# Altium schematic sheet Peripheral.SchDoc(Peripheral)
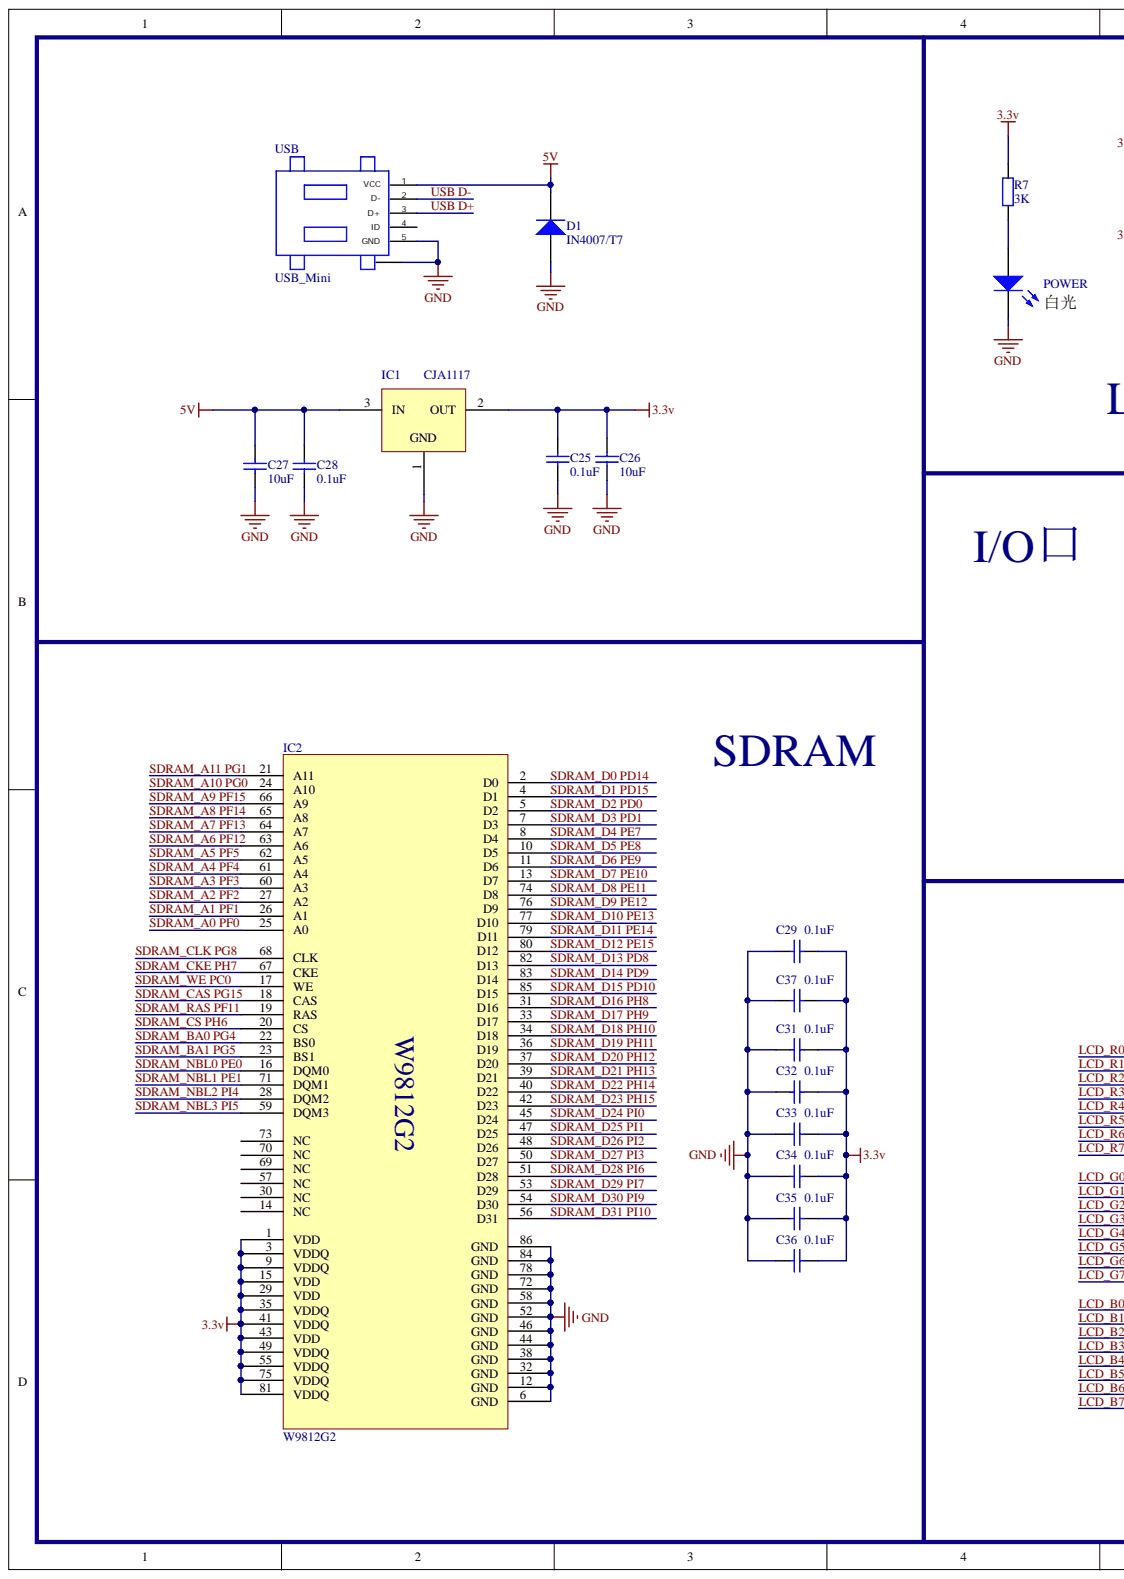
[diagram p0: Peripheral.SchDoc, left]
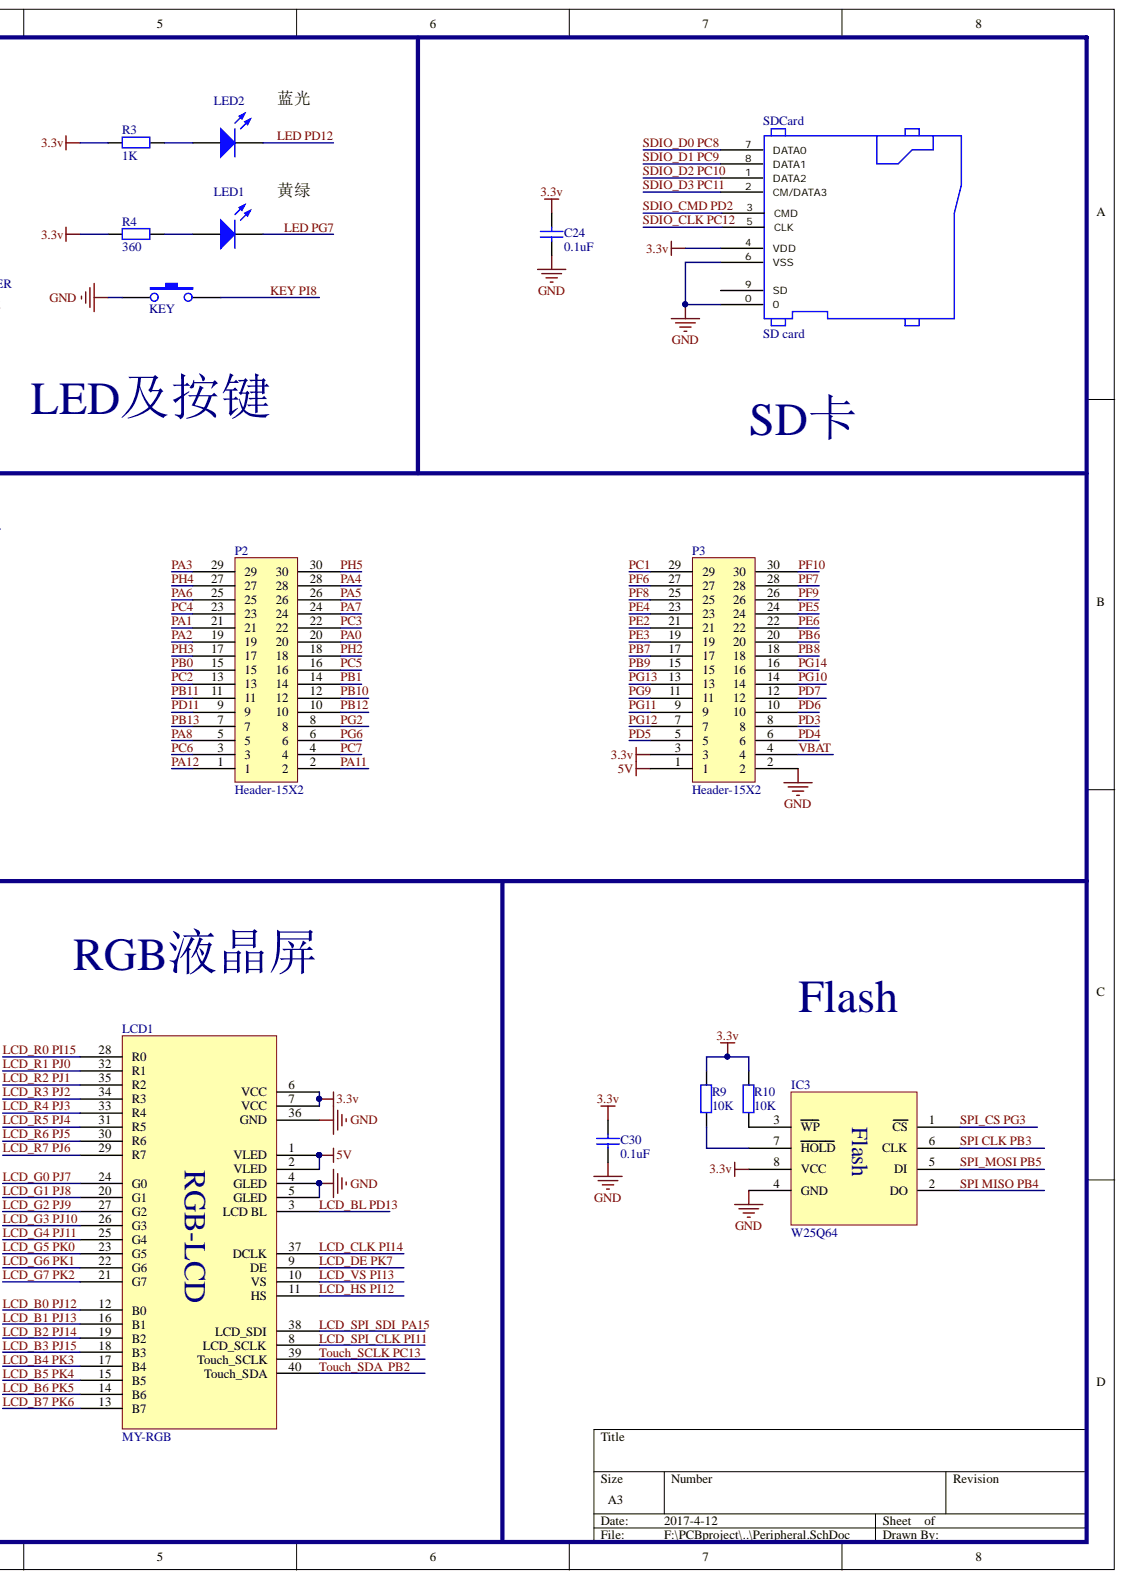
[diagram p1: Peripheral.SchDoc, right]

## Parts
C24  0.1uF  [0603-cap]
C25  0.1uF  [0603-cap]
C26  10uF  [0603-cap]
C27  10uF  [0603-cap]
C28  0.1uF  [0603-cap]
C29  0.1uF  [0603-cap]
C30  0.1uF  [0603-cap]
C31  0.1uF  [0603-cap]
C32  0.1uF  [0603-cap]
C33  0.1uF  [0603-cap]
C34  0.1uF  [0603-cap]
C35  0.1uF  [0603-cap]
C36  0.1uF  [0603-cap]
C37  0.1uF  [0603-cap]
D1  IN4007/T7  [SOD-223]
IC1  CJA1117  [SOT89]
IC2  W9812G2  [TSSOP-86P-0.5mm]
IC3  W25Q64  [SOIC-W-8]
KEY  按键  [SWT6*3]  (value not drawn)
LCD1  MY-RGB  [FPC40P-0.5MM]
LED1  LED  [D0603]
LED2  LED  [D0603]
P2  Header-15X2  [SMD-15x2]
P3  Header-15X2  [SMD-15x2]
POWER  LED  [D0603]
R3  1K  [0603-res]
R4  360  [0603-res]
R7  3K  [0603-res]
R9  10K  [0603-res]
R10  10K  [0603-res]
SDCard  SD card  [SD_CARD]
USB  USB_Mini  [沉板miniUSB]

## Nets
3.3V: C24.2 C25.2 C26.2 C29.2 C30.2 C31.2 C32.2 C33.2 C34.2 C35.2 C36.2 C37.2 IC1.2 IC2.1(VDD) IC2.3(VDDQ) IC2.9(VDDQ) IC2.15(VDD) IC2.29(VDD) IC2.35(VDDQ) IC2.41(VDDQ) IC2.43(VDD) IC2.49(VDDQ) IC2.55(VDDQ) IC2.75(VDDQ) IC2.81(VDDQ) IC3.8(VCC) LCD1.6(VCC) LCD1.7(VCC) P3.3 R3.1 R4.1 R7.1 R9.2 R10.2 SDCard.4(VDD)
5V: C27.2 C28.2 D1.2 IC1.3 LCD1.1(VLED) LCD1.2(VLED) P3.1 USB.1(VCC)
GND: C24.1 C25.1 C26.1 C27.1 C28.1 C29.1 C30.1 C31.1 C32.1 C33.1 C34.1 C35.1 C36.1 C37.1 D1.1 IC1.1 IC2.6(GND) IC2.12(GND) IC2.32(GND) IC2.38(GND) IC2.44(GND) IC2.46(GND) IC2.52(GND) IC2.58(GND) IC2.72(GND) IC2.78(GND) IC2.84(GND) IC2.86(GND) IC3.4(GND) KEY.1 LCD1.4(GLED) LCD1.5(GLED) LCD1.36(GND) P3.2 POWER.2 SDCard.0 SDCard.6(VSS) USB.0 USB.5(GND)
KEY PI8: KEY.2
LCD_B0 PJ12: LCD1.12(B0)
LCD_B1 PJ13: LCD1.16(B1)
LCD_B2 PJ14: LCD1.19(B2)
LCD_B3 PJ15: LCD1.18(B3)
LCD_B4 PK3: LCD1.17(B4)
LCD_B5 PK4: LCD1.15(B5)
LCD_B6 PK5: LCD1.14(B6)
LCD_B7 PK6: LCD1.13(B7)
LCD_BL PD13: LCD1.3(LCD_BL)
LCD_CLK PI14: LCD1.37(DCLK)
LCD_DE PK7: LCD1.9(DE)
LCD_G0 PJ7: LCD1.24(G0)
LCD_G1 PJ8: LCD1.20(G1)
LCD_G2 PJ9: LCD1.27(G2)
LCD_G3 PJ10: LCD1.26(G3)
LCD_G4 PJ11: LCD1.25(G4)
LCD_G5 PK0: LCD1.23(G5)
LCD_G6 PK1: LCD1.22(G6)
LCD_G7 PK2: LCD1.21(G7)
LCD_HS PI12: LCD1.11(HS)
LCD_R0 PI15: LCD1.28(R0)
LCD_R1 PJ0: LCD1.32(R1)
LCD_R2 PJ1: LCD1.35(R2)
LCD_R3 PJ2: LCD1.34(R3)
LCD_R4 PJ3: LCD1.33(R4)
LCD_R5 PJ4: LCD1.31(R5)
LCD_R6 PJ5: LCD1.30(R6)
LCD_R7 PJ6: LCD1.29(R7)
LCD_SPI_CLK PI11: LCD1.8(LCD_SCLK)
LCD_SPI_SDI  PA15: LCD1.38(LCD_SDI)
LCD_VS PI13: LCD1.10(VS)
LED PD12: LED2.2
LED PG7: LED1.2
PA0: P2.20
PA1: P2.21
PA2: P2.19
PA3: P2.29
PA4: P2.28
PA5: P2.26
PA6: P2.25
PA7: P2.24
PA8: P2.5
PA11: P2.2
PA12: P2.1
PB0: P2.15
PB1: P2.14
PB6: P3.20
PB7: P3.17
PB8: P3.18
PB9: P3.15
PB10: P2.12
PB11: P2.11
PB12: P2.10
PB13: P2.7
PC1: P3.29
PC2: P2.13
PC3: P2.22
PC4: P2.23
PC5: P2.16
PC6: P2.3
PC7: P2.4
PD3: P3.8
PD4: P3.6
PD5: P3.5
PD6: P3.10
PD7: P3.12
PD11: P2.9
PE2: P3.21
PE3: P3.19
PE4: P3.23
PE5: P3.24
PE6: P3.22
PF6: P3.27
PF7: P3.28
PF8: P3.25
PF9: P3.26
PF10: P3.30
PG2: P2.8
PG6: P2.6
PG9: P3.11
PG10: P3.14
PG11: P3.9
PG12: P3.7
PG13: P3.13
PG14: P3.16
PH2: P2.18
PH3: P2.17
PH4: P2.27
PH5: P2.30
SDIO_CLK PC12: SDCard.5(CLK)
SDIO_CMD PD2: SDCard.3(CMD)
SDIO_D0 PC8: SDCard.7(DATA0)
SDIO_D1 PC9: SDCard.8(DATA1)
SDIO_D2 PC10: SDCard.1(DATA2)
SDIO_D3 PC11: SDCard.2(CM/DATA3)
SDRAM_A0 PF0: IC2.25(A0)
SDRAM_A1 PF1: IC2.26(A1)
SDRAM_A2 PF2: IC2.27(A2)
SDRAM_A3 PF3: IC2.60(A3)
SDRAM_A4 PF4: IC2.61(A4)
SDRAM_A5 PF5: IC2.62(A5)
SDRAM_A6 PF12: IC2.63(A6)
SDRAM_A7 PF13: IC2.64(A7)
SDRAM_A8 PF14: IC2.65(A8)
SDRAM_A9 PF15: IC2.66(A9)
SDRAM_A10 PG0: IC2.24(A10)
SDRAM_A11 PG1: IC2.21(A11)
SDRAM_BA0 PG4: IC2.22(BS0)
SDRAM_BA1 PG5: IC2.23(BS1)
SDRAM_CAS PG15: IC2.18(CAS)
SDRAM_CKE PH7: IC2.67(CKE)
SDRAM_CLK PG8: IC2.68(CLK)
SDRAM_CS PH6: IC2.20(CS)
SDRAM_D0 PD14: IC2.2(D0)
SDRAM_D1 PD15: IC2.4(D1)
SDRAM_D2 PD0: IC2.5(D2)
SDRAM_D3 PD1: IC2.7(D3)
SDRAM_D4 PE7: IC2.8(D4)
SDRAM_D5 PE8: IC2.10(D5)
SDRAM_D6 PE9: IC2.11(D6)
SDRAM_D7 PE10: IC2.13(D7)
SDRAM_D8 PE11: IC2.74(D8)
SDRAM_D9 PE12: IC2.76(D9)
SDRAM_D10 PE13: IC2.77(D10)
SDRAM_D11 PE14: IC2.79(D11)
SDRAM_D12 PE15: IC2.80(D12)
SDRAM_D13 PD8: IC2.82(D13)
SDRAM_D14 PD9: IC2.83(D14)
SDRAM_D15 PD10: IC2.85(D15)
SDRAM_D16 PH8: IC2.31(D16)
SDRAM_D17 PH9: IC2.33(D17)
SDRAM_D18 PH10: IC2.34(D18)
SDRAM_D19 PH11: IC2.36(D19)
SDRAM_D20 PH12: IC2.37(D20)
SDRAM_D21 PH13: IC2.39(D21)
SDRAM_D22 PH14: IC2.40(D22)
SDRAM_D23 PH15: IC2.42(D23)
SDRAM_D24 PI0: IC2.45(D24)
SDRAM_D25 PI1: IC2.47(D25)
SDRAM_D26 PI2: IC2.48(D26)
SDRAM_D27 PI3: IC2.50(D27)
SDRAM_D28 PI6: IC2.51(D28)
SDRAM_D29 PI7: IC2.53(D29)
SDRAM_D30 PI9: IC2.54(D30)
SDRAM_D31 PI10: IC2.56(D31)
SDRAM_NBL0 PE0: IC2.16(DQM0)
SDRAM_NBL1 PE1: IC2.71(DQM1)
SDRAM_NBL2 PI4: IC2.28(DQM2)
SDRAM_NBL3 PI5: IC2.59(DQM3)
SDRAM_RAS PF11: IC2.19(RAS)
SDRAM_WE PC0: IC2.17(WE)
SPI CLK PB3: IC3.6(CLK)
SPI MISO PB4: IC3.2(DO)
SPI_CS PG3: IC3.1(CS)
SPI_MOSI PB5: IC3.5(DI)
TOUCH_SCLK PC13: LCD1.39(Touch_SCLK)
TOUCH_SDA  PB2: LCD1.40(Touch_SDA)
USB D+: USB.3(D+)
USB D-: USB.2(D-)
VBAT: P3.4
NetIC3_3: IC3.3(WP) R10.1
NetIC3_7: IC3.7(HOLD) R9.1
NetLED1_1: LED1.1 R4.2
NetLED2_1: LED2.1 R3.2
NetPOWER_1: POWER.1 R7.2

Not connected: IC2 14(NC) 30(NC) 57(NC) 69(NC) 70(NC) 73(NC); SDCard 9; USB 4(ID)

## Library data of the parts
C24-C37 = Cap1: Component Kind: Standard; Library Name: MY.SCHLIB
  C24 C25 C28-C37: value: 0.1uF
  C26 C27: value: 10uF
D1 = Diode: Component Kind: Standard; Library Name: MY.SCHLIB
IC3 = W25QXX: Component Kind: Standard; Library Name: MY-IC.SchLib
R3 R4 R7 R9 R10 = Res: Component Kind: Standard; Library Name: MY.SCHLIB
  R3: value: 1K
  R4: value: 360
  R7: value: 3K
  R9 R10: value: 10K
IC1 = CJA1117: Component Kind: Standard; Library Name: 稳压管.SCHLIB
IC2 = W9812G2: Component Kind: Standard; Library Name: MY-IC.SchLib
KEY = 按键: Component Kind: Standard; Library Name: MY.SCHLIB
LCD1 = MY-RGB: Component Kind: Standard; Library Name: MY-显示.SchLib
LED1 LED2 POWER = LED: Component Kind: Standard; Library Name: MY.SCHLIB
P2 P3 = Header-15X2: Component Kind: Standard; Library Name: 接口.SchLib
SDCard = SD card: Component Kind: Standard; Library Name: MY-IC.SchLib
USB = USB_Mini: Component Kind: Standard; Library Name: 接口.SchLib
